# Altium schematic sheet mbedXdotShield-V_1_2_0_1.SchDoc(mbedXdotShield-V_1_2_0_1)
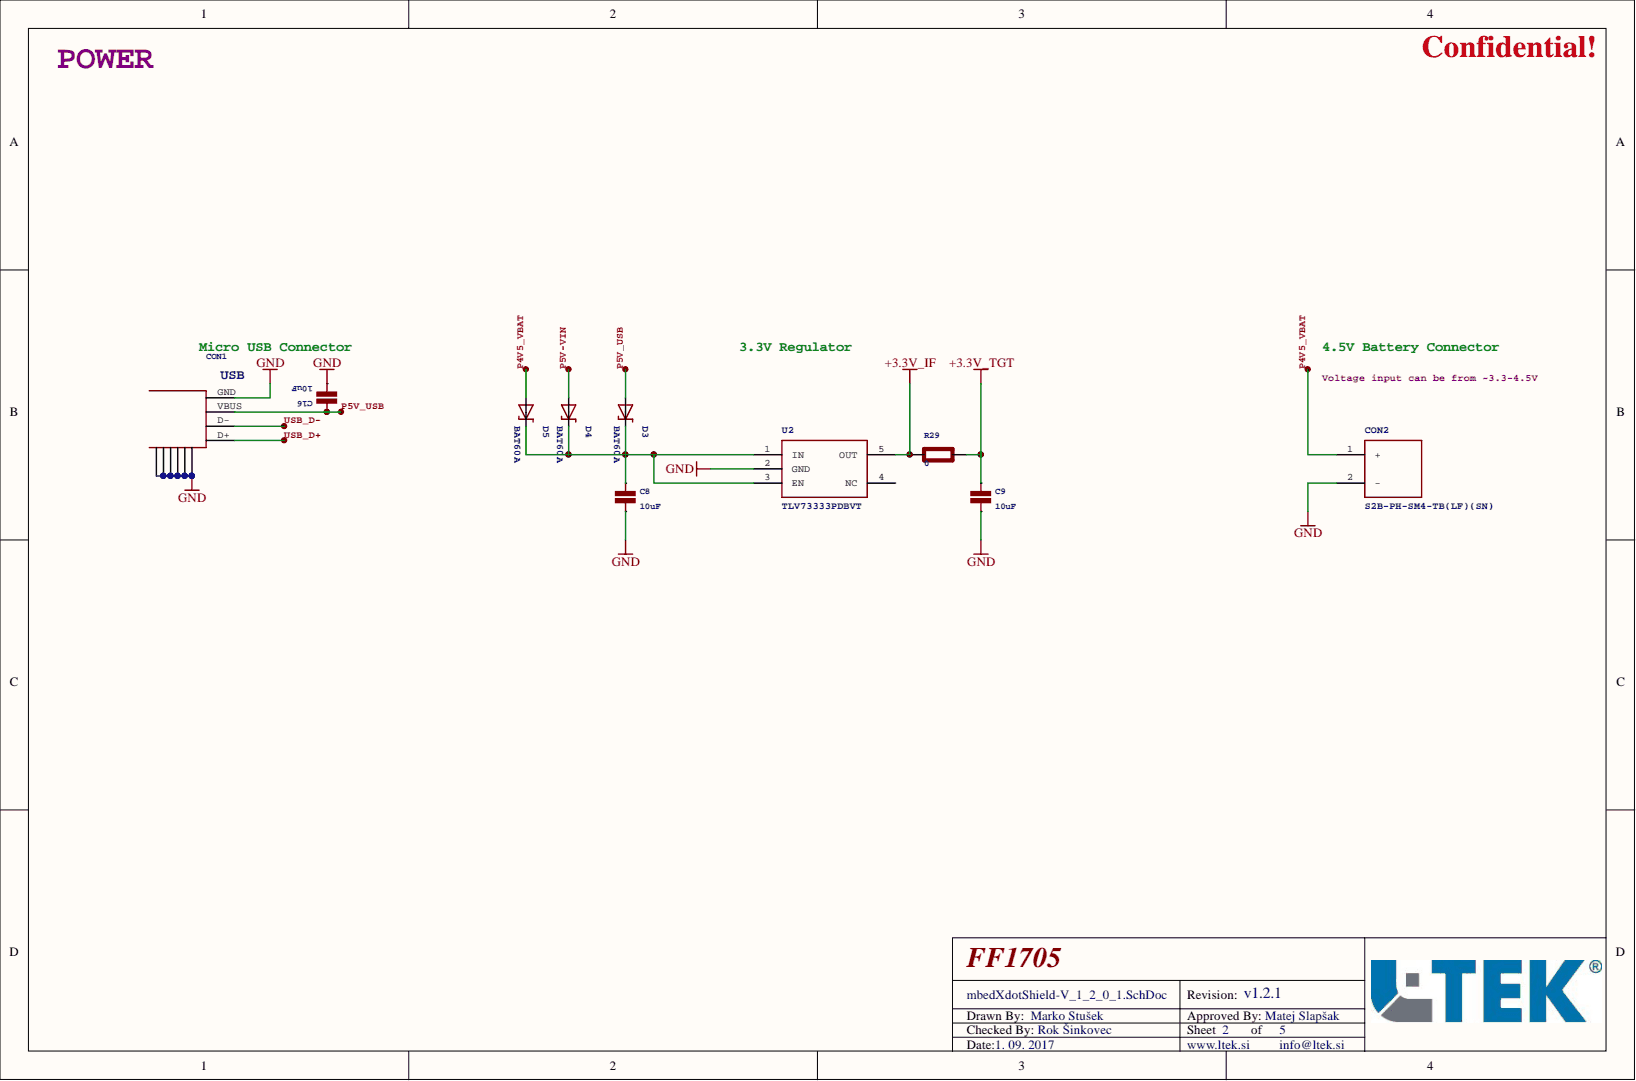
[diagram p0: mbedXdotShield-V_1_2_0_1.SchDoc]

## Parts
C8  10uF  [0603]
C9  10uF  [0603]
C16  10uF  [0603]
CON1  47346-0001  [USB-MICRO-B-H]  (value not drawn)
CON2  S2B-PH-SM4-TB(LF)(SN)  [S2B-PH-SM4-TB(LF)(SN)]
D3  BAT60A  [SOD323]
D4  BAT60A  [SOD323]
D5  BAT60A  [SOD323]
R29  0  [0805]
U2  TLV73333PDBVT  [SOT23-5]

## Nets
+3.3V_IF: R29.1 U2.5(OUT)
+3.3V_TGT: C9.1 R29.2
GND: C8.2 C9.2 C16.2 CON1.GND CON1.GND1 CON1.GND2 CON1.GND3 CON1.GND4 CON1.GND5 CON1.GND6 CON2.2 U2.2(GND)
P4V5_VBAT: CON2.1 D5.A
P5V-VIN: D4.A
P5V_USB: C16.1 CON1.VBUS D3.A
USB_D+: CON1.D+
USB_D-: CON1.D-
NetC8_1: C8.1 D3.K D4.K D5.K U2.1(IN) U2.3(EN)

Not connected: U2 4(NC)

## Library data of the parts
C8 C9 C16 = CAPACITOR0603: Capacitor; Component Kind: Standard; Library Name: mbed-HDK-RLCQ.IntLib
CON1 = 47346-0001: USB Receptacle Horizontal Micro Type B; MF: MOLEX; USB: USB; OC-NEWARK: 1568026; OC-MOUSER: 538-47346-0001; MFN: 47346-0001; Component Kind: Standard; Library Name: mbedXdotShield-V_1_2_0.IntLib
CON2 = S2B-PH-SM4-TB(LF)(SN): 2 Position 2 mm JST PH Series Pitch Surface Mount Side Entry Shrouded Header; OC-NEWARK: 38K8067; OC-DIGIKEY: 455-1749-1-ND; MFN: S2B-PH-SM4-TB(LF)(SN); MF: JST; Component Kind: Standard; Library Name: mbed-HDK-Connector.IntLib
D3-D5 = BAT60A: SCHOTTKY DIODE; MFN: BAT60AE6327HTSA1; MF: Infineon; OC-NEWARK: 85X4127; OC-MOUSER: 726-BAT60AE6327; OC-DIGIKEY: BAT60AE6327HTSA1CT-ND; Component Kind: Standard; Library Name: mbed-HDK-Transistor.IntLib
R29 = RESISTOR0805: Resistor; Component Kind: Standard; Library Name: mbed-HDK-RLC.IntLib
U2 = TLV73333PDBVT: LDO Fixed Regulator 3.3v, 300mA; MF: Texas Instruments; OC-NEWARK: 2455145; OC-MOUSER: 595-TLV73333PDBVT; OC-DIGIKEY: 296-38492-1-ND; MFN: TLV73333PDBVT; Component Kind: Standard; Library Name: mbed-HDK-Power.IntLib
